# Altium schematic sheet mcu_with_peripherals.SchDoc
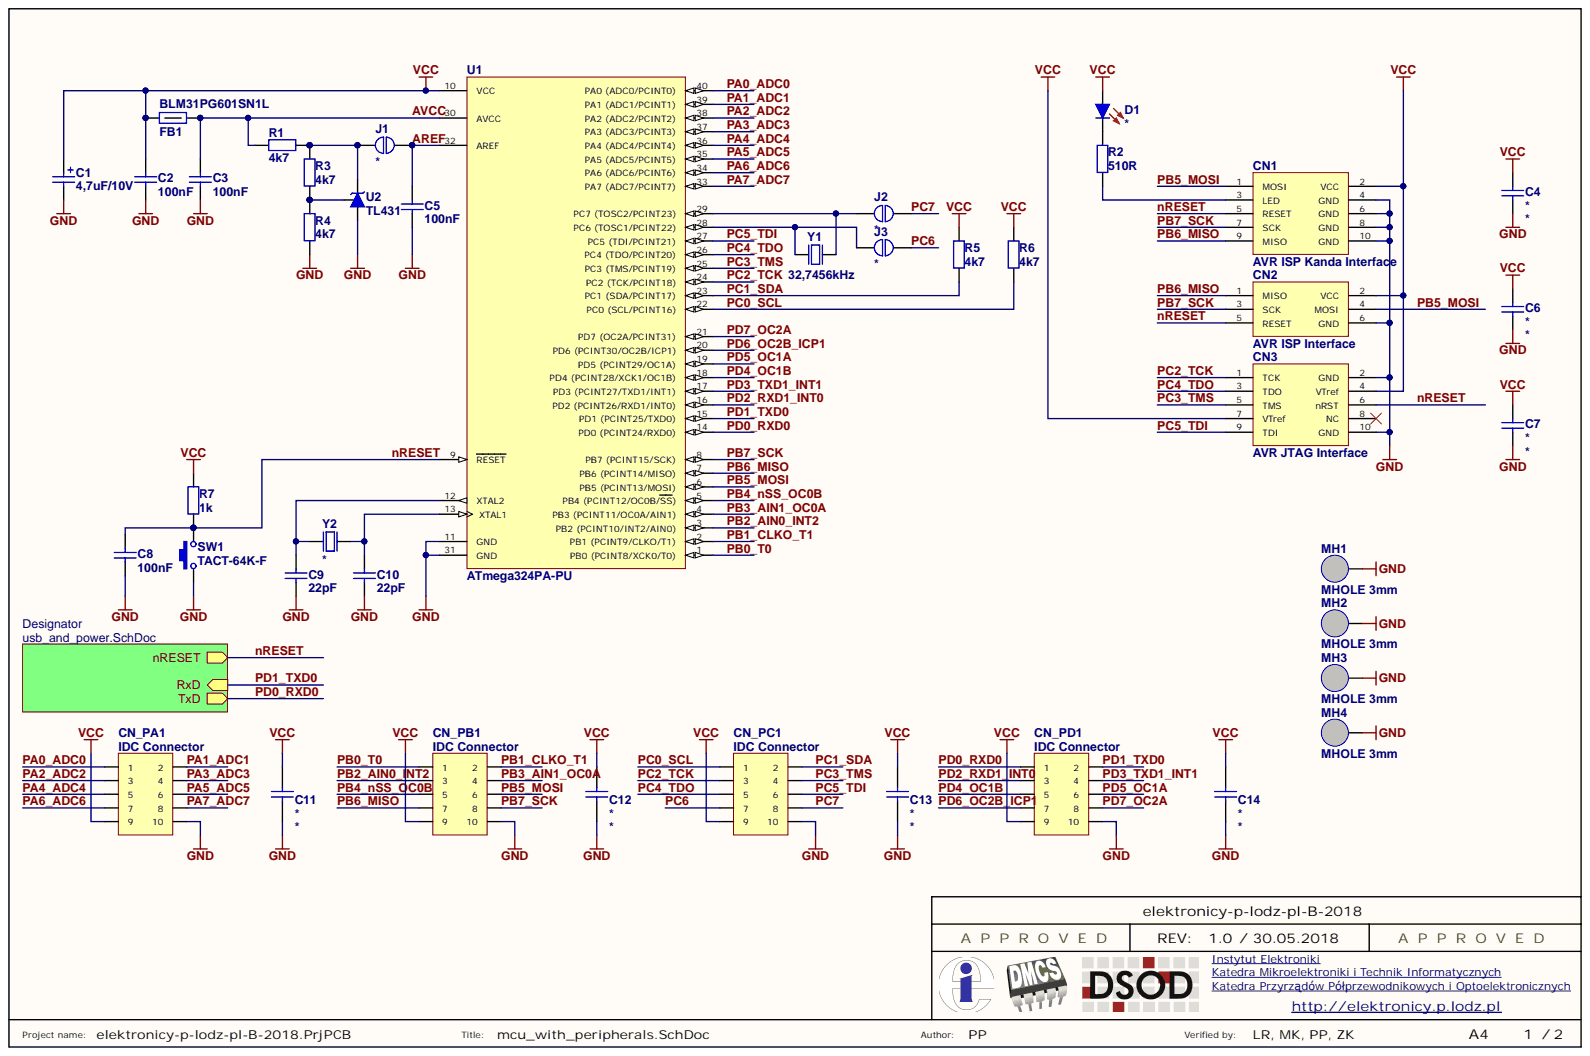
[diagram p0: mcu_with_peripherals.SchDoc]

## Parts
C1  4,7uF/10V  [SMDB]
C2  100nF  [C1206]
C3  100nF  [C1206]
C4  (no value)  [C1206]
C5  100nF  [C1206]
C6  (no value)  [C1206]
C7  (no value)  [C1206]
C8  100nF  [C1206]
C9  22pF  [C1206]
C10  22pF  [C1206]
C11  (no value)  [C1206]
C12  (no value)  [C1206]
C13  (no value)  [C1206]
C14  (no value)  [C1206]
CN1  AVR ISP Kanda Interface  [IDC10]
CN2  AVR ISP Interface  [IDC6]
CN3  AVR JTAG Interface  [IDC10]
CN_PA1  IDC Connector  [IDC10]
CN_PB1  IDC Connector  [IDC10]
CN_PC1  IDC Connector  [IDC10]
CN_PD1  IDC Connector  [IDC10]
D1  (no value)  [LED1206R]
FB1  BLM31PG601SN1L  [FB1206]
J1  (no value)  [JMP2_0603_NO]
J2  (no value)  [JMP2_0603_NO]
J3  (no value)  [JMP2_0603_NO]
MH1  MHOLE 3mm  [3mm screw drill III]  (no BOM)
MH2  MHOLE 3mm  [3mm screw drill III]  (no BOM)
MH3  MHOLE 3mm  [3mm screw drill III]  (no BOM)
MH4  MHOLE 3mm  [3mm screw drill III]  (no BOM)
R1  4k7  [R1206]
R2  510R  [R1206]
R3  4k7  [R1206]
R4  4k7  [R1206]
R5  4k7  [R1206]
R6  4k7  [R1206]
R7  1k  [R1206]
SW1  TACT-64K-F  [TACT-64K-F]
U1  ATmega324PA-PU  [DIP40_PRECISION_W_IC]
U2  TL431  [SOT-23]
Y1  32,7456kHz  [TC38_3x8_H]
Y2  (no value)  [HC49-S]

## Nets
GND: C1.2 C2.1 C3.1 C4.1 C5.1 C6.1 C7.1 C8.1 C9.1 C10.1 C11.1 C12.1 C13.1 C14.1 CN1.4(GND) CN1.6(GND) CN1.8(GND) CN1.10(GND) CN2.6(GND) CN3.2(GND) CN3.10(GND) CN_PA1.10 CN_PB1.10 CN_PC1.10 CN_PD1.10 MH1.1 MH2.1 MH3.1 MH4.1 R4.2 SW1.1 U1.11(GND) U1.31(GND) U2.3
VCC: C1.1 C2.2 C4.2 C6.2 C7.2 C11.2 C12.2 C13.2 C14.2 CN1.2(VCC) CN2.2(VCC) CN3.4(VTref) CN3.7(VTref) CN_PA1.9 CN_PB1.9 CN_PC1.9 CN_PD1.9 D1.1 FB1.1 R5.2 R6.2 R7.2 U1.10(VCC)
AREF: C5.2 J1.2 U1.32(AREF)
AVCC: C3.2 FB1.2 R1.1 U1.30(AVCC)
nRESET: C8.2 CN1.5(RESET) CN2.5(RESET) CN3.6(nRST) R7.1 SW1.2 U1.9(RESET)
PA0_ADC0: CN_PA1.1 U1.40(PA0_(ADC0/PCINT0))
PA1_ADC1: CN_PA1.2 U1.39(PA1_(ADC1/PCINT1))
PA2_ADC2: CN_PA1.3 U1.38(PA2_(ADC2/PCINT2))
PA3_ADC3: CN_PA1.4 U1.37(PA3_(ADC3/PCINT3))
PA4_ADC4: CN_PA1.5 U1.36(PA4_(ADC4/PCINT4))
PA5_ADC5: CN_PA1.6 U1.35(PA5_(ADC5/PCINT5))
PA6_ADC6: CN_PA1.7 U1.34(PA6_(ADC6/PCINT6))
PA7_ADC7: CN_PA1.8 U1.33(PA7_(ADC7/PCINT7))
PB0_T0: CN_PB1.1 U1.1(PB0_(PCINT8/XCK0/T0))
PB1_CLKO_T1: CN_PB1.2 U1.2(PB1_(PCINT9/CLKO/T1))
PB2_AIN0_INT2: CN_PB1.3 U1.3(PB2_(PCINT10/INT2/AIN0))
PB3_AIN1_OC0A: CN_PB1.4 U1.4
PB4_nSS_OC0B: CN_PB1.5 U1.5
PB5_MOSI: CN1.1(MOSI) CN2.4(MOSI) CN_PB1.6 U1.6(PB5_(PCINT13/MOSI))
PB6_MISO: CN1.9(MISO) CN2.1(MISO) CN_PB1.7 U1.7(PB6_(PCINT14/MISO))
PB7_SCK: CN1.7(SCK) CN2.3(SCK) CN_PB1.8 U1.8(PB7_(PCINT15/SCK))
PC0_SCL: CN_PC1.1 R6.1 U1.22(PC0_(SCL/PCINT16))
PC1_SDA: CN_PC1.2 R5.1 U1.23(PC1_(SDA/PCINT17))
PC2_TCK: CN3.1(TCK) CN_PC1.3 U1.24(PC2_(TCK/PCINT18))
PC3_TMS: CN3.5(TMS) CN_PC1.4 U1.25(PC3_(TMS/PCINT19))
PC4_TDO: CN3.3(TDO) CN_PC1.5 U1.26(PC4_(TDO/PCINT20))
PC5_TDI: CN3.9(TDI) CN_PC1.6 U1.27(PC5_(TDI/PCINT21))
PC6: CN_PC1.7 J3.2
PC7: CN_PC1.8 J2.2
PD0_RXD0: CN_PD1.1 U1.14(PD0_(PCINT24/RXD0))
PD1_TXD0: CN_PD1.2 U1.15(PD1_(PCINT25/TXD0))
PD2_RXD1_INT0: CN_PD1.3 U1.16(PD2_(PCINT26/RXD1/INT0))
PD3_TXD1_INT1: CN_PD1.4 U1.17(PD3_(PCINT27/TXD1/INT1))
PD4_OC1B: CN_PD1.5 U1.18(PD4_(PCINT28/XCK1/OC1B))
PD5_OC1A: CN_PD1.6 U1.19(PD5_(PCINT29/OC1A))
PD6_OC2B_ICP1: CN_PD1.7 U1.20(PD6_(PCINT30/OC2B/ICP1))
PD7_OC2A: CN_PD1.8 U1.21(PD7_(OC2A/PCINT31))
NetC9_2: C9.2 U1.12(XTAL2) Y2.1
NetC10_2: C10.2 U1.13(XTAL1) Y2.2
NetCN1_3: CN1.3(LED) R2.1
NetCN3_8: CN3.8(NC)
NetD1_2: D1.2 R2.2
NetJ1_1: J1.1 R1.2 R3.1 U2.1
NetJ2_1: J2.1 U1.29(PC7_(TOSC2/PCINT23)) Y1.1
NetJ3_1: J3.1 U1.28(PC6_(TOSC1/PCINT22)) Y1.2
NetR3_2: R3.2 R4.1 U2.2

## Library data of the parts
C1 = Cap Pol Tant: Polarized Tantalum Capacitor; Component Kind: Standard; ComponentLink1Description: datasheet; ComponentLink2Description: supplier; Library Name: capacitors.IntLib; Value: 4,7uF/10V
C2-C14 = Cap: Capacitor; Component Kind: Standard; ComponentLink1Description: datasheet; ComponentLink2Description: supplier; Library Name: capacitors.IntLib; Simulation: CAP
  C2 C3 C5 C8: Value: 100nF
  C9 C10: Value: 22pF
CN1 = AVR ISP Kanda Interface: Kanda ISP Programming Header for AVR MCU's; Component Kind: Standard; Library Name: mcu_prog_and_debug_connectors.IntLib
CN2 = AVR ISP Interface: ISP Programming Header for AVR MCU's; Component Kind: Standard; Library Name: mcu_prog_and_debug_connectors.IntLib
CN3 = AVR JTAG Interface: JTAG Header for AVR MCU's; Component Kind: Standard; Library Name: mcu_prog_and_debug_connectors.SchLib
CN_PA1 CN_PB1 CN_PC1 CN_PD1 = CN_5x2: Component Kind: Standard; Library Name: idc.IntLib
D1 = LED_RED: RED LED; Component Kind: Standard; Library Name: leds.IntLib; Published: 04-Apr-2006
FB1 = BLM31PG601SN1L: Ferrite Bead; Imp.@ 100MHz:600R; Mounting: SMD; 1,5A; Case: 1206; Component Kind: Standard; Library Name: emi_emc_ferrites.IntLib
J1-J3 = JUMPER2_NO: Solder Jumper - Open; Component Kind: Standard; Library Name: jumpers_and_circuit_brakers.IntLib
MH1-MH4 = MHOLE_3MM: 3mm Mounting Hole; Library Name: mechanical_other.IntLib
R1 R3-R7 = Res: Resistor; Component Kind: Standard; ComponentLink1Description: datasheet; ComponentLink2Description: supplier; Library Name: resistors.IntLib; Published: 19-Feb-2006; Simulation: RES
  R1 R3-R6: Value: 4k7
  R7: Value: 1k
R2 = Res: Resistor; Value: 4k7; Component Kind: Standard; ComponentLink1Description: datasheet; ComponentLink2Description: supplier; Library Name: resistors.IntLib; Published: 19-Feb-2006; Simulation: RES
SW1 = TACT-64K-F: 6x6mm THT Tactile SPST-NO Switch; Component Kind: Standard; Published: 30-Jun-2006; Library Name: microswitches.IntLib
U1 = ATmega324PA-PU: 8-bit AVR Microcontroller, 32KB Flash, 1KB EEPROM, 2KB SRAM, 40-pin PDIP, Industrial Grade (-40°C to 85°C); Code_JEDEC: MS-011-AC; Component Kind: Standard; ComponentLink1Description: Manufacturer URL; ComponentLink1URL: http://www.atmel.com/; ComponentLink2Description: ATmega164A/164PA/324A/324PA/644A/644PA/1284/1284P Datasheet; ComponentLink2URL: http://www.atmel.com/dyn/resources/prod_documents/doc8272.pdf; ComponentLink3Description: ATmega164A/164PA/324A/324PA/644A/644PA/1284/1284P Summary; ComponentLink3URL: http://www.atmel.com/dyn/resources/prod_documents/8272S.pdf; DatasheetVersion: revC, Jun-2011; PackageDescription: 40-lead (0.600"/15.24mm Wide) Plastic Dual Inline Package (PDIP); PackageReference: 40P6; PackageVersion: revB, Sep-2001; Library Name: mcus_atmel.SchLib
U2 = TL431BCDBZ: 0,5% Precision Programmable Reference; Component Kind: Standard; Library Name: reference_voltage_sources.IntLib
Y1 = Clock XTAL: Crystal Clock Oscillator; Component Kind: Standard; ComponentLink1Description: datasheet; ComponentLink2Description: supplier; Library Name: quartz_crystals_and_filters.IntLib; Value: 32,7456kHz
Y2 = XTAL_2PIN: Crystal Oscillator; Component Kind: Standard; ComponentLink1Description: datasheet; ComponentLink2Description: supplier; Library Name: quartz_crystals_and_filters.IntLib
